# Altium schematic sheet 03-WWVB_Path.SchDoc(03-WWVB_Path)
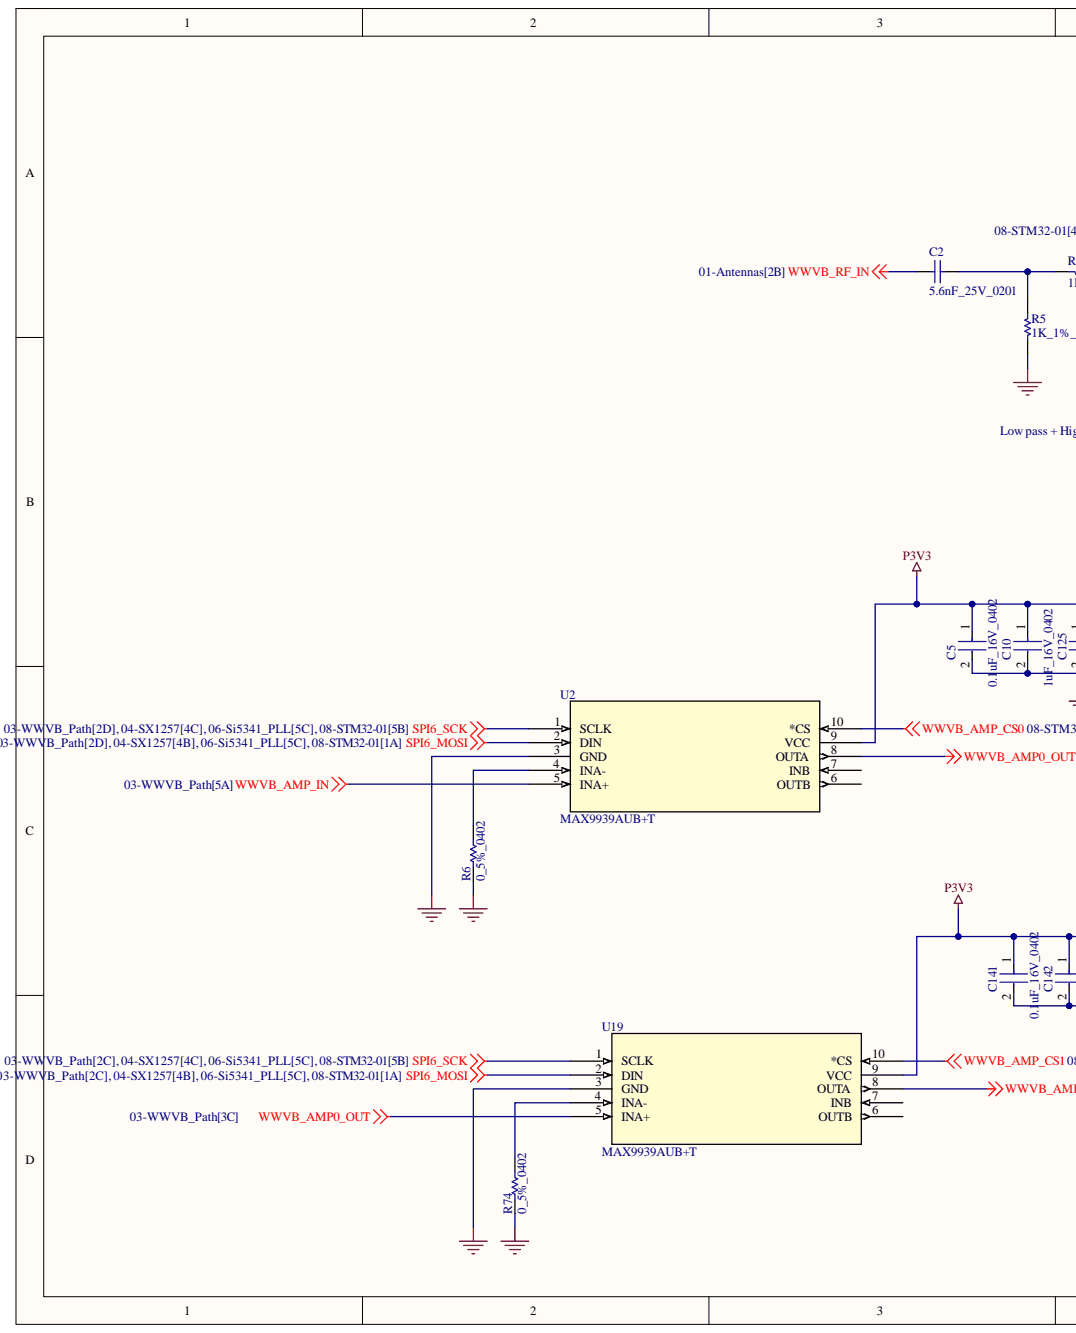
[diagram p0: 03-WWVB_Path.SchDoc, left]
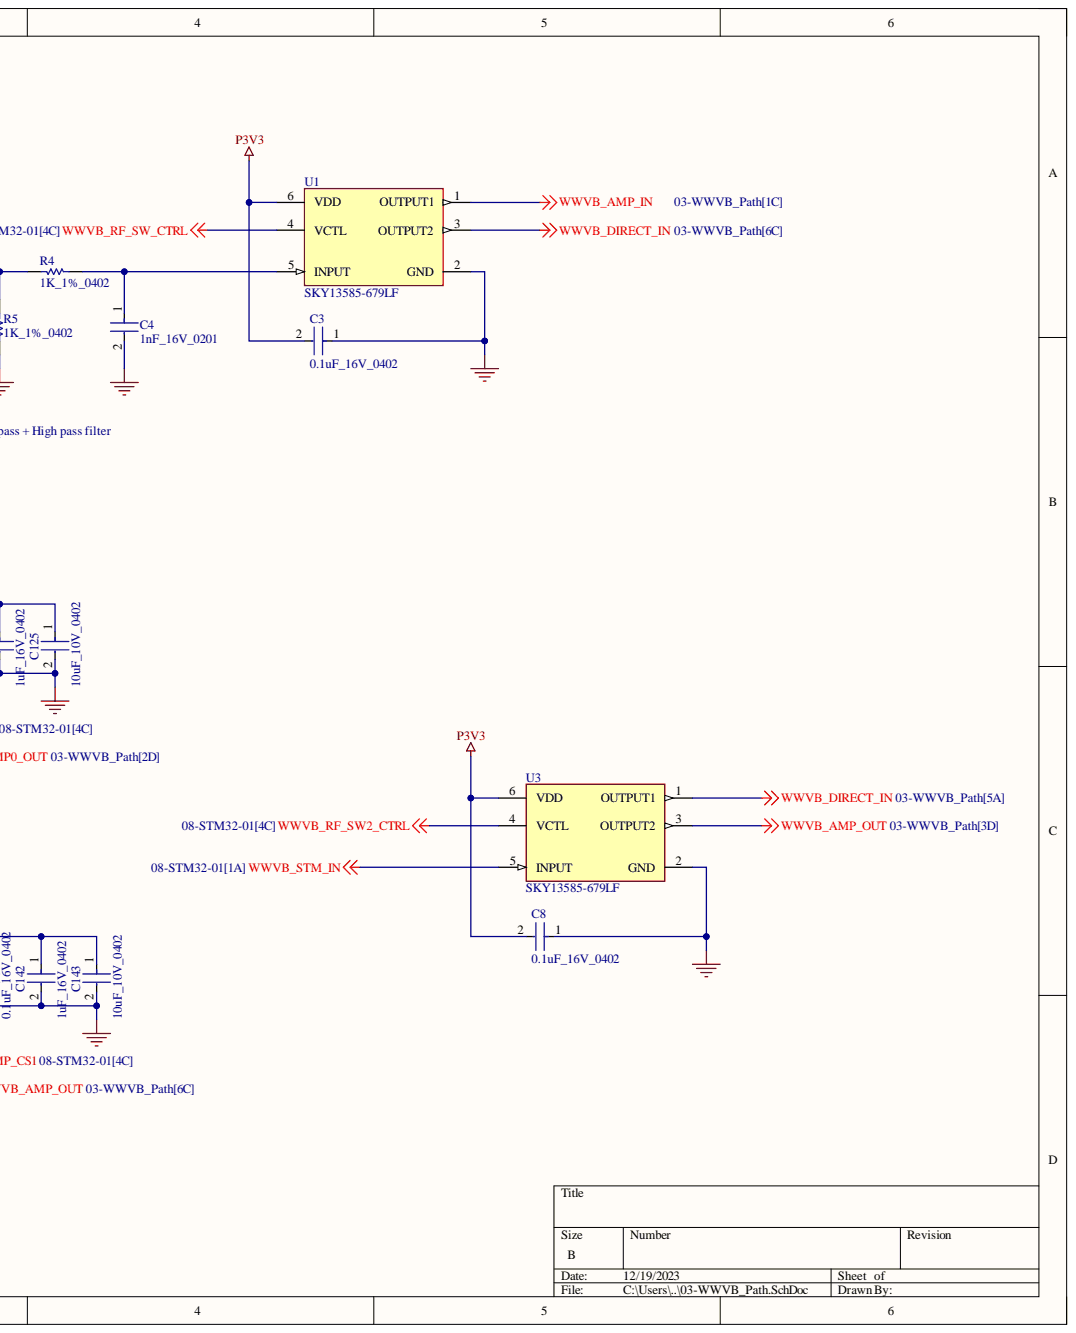
[diagram p1: 03-WWVB_Path.SchDoc, right]

## Parts
C2  5.6nF_25V_0201  [CAPC0603X33X15ML03T05]
C3  0.1uF_16V_0402  [FP-C0402-BB-MFG]
C4  1nF_16V_0201  [FP-0201-L_0_6_0_09-W_0_3-MFG]
C5  0.1uF_16V_0402  [FP-C0402-BB-MFG]
C8  0.1uF_16V_0402  [FP-C0402-BB-MFG]
C10  1uF_16V_0402  [FP-0402-L_1_0_0_05-W_0_5-IPC_A]
C125  10uF_10V_0402  [FP-CL05A106MP5NUNC-MFG]
C141  0.1uF_16V_0402  [FP-C0402-BB-MFG]
C142  1uF_16V_0402  [FP-0402-L_1_0_0_05-W_0_5-IPC_A]
C143  10uF_10V_0402  [FP-CL05A106MP5NUNC-MFG]
R4  1K_1%_0402  [RESC1005X40X25NL05T05]
R5  1K_1%_0402  [RESC1005X40X25NL05T05]
R6  0_5%_0402  [RESC1005X40X25NL05T10]
R74  0_5%_0402  [RESC1005X40X25NL05T10]
U1  SKY13585-679LF  [FP-SKY13585-679LF-MFG]
U2  MAX9939AUB+T  [21-0061L_MXM]
U3  SKY13585-679LF  [FP-SKY13585-679LF-MFG]
U19  MAX9939AUB+T  [21-0061L_MXM]

## Nets
GND: C3.1 C4.2 C5.2 C8.1 C10.2 C125.2 C141.2 C142.2 C143.2 R5.1 R6.1 R74.1 U1.2(GND) U2.3(GND) U3.2(GND) U19.3(GND)
P3V3: C3.2 C5.1 C8.2 C10.1 C125.1 C141.1 C142.1 C143.1 U1.6(VDD) U2.9(VCC) U3.6(VDD) U19.9(VCC)
SPI6_MOSI: U2.2(DIN) U19.2(DIN)
SPI6_SCK: U2.1(SCLK) U19.1(SCLK)
WWVB_AMP0_OUT: U2.8(OUTA) U19.5(INA+)
WWVB_AMP_CS0: U2.10(*CS)
WWVB_AMP_CS1: U19.10(*CS)
WWVB_AMP_IN: U1.1(OUTPUT1) U2.5(INA+)
WWVB_AMP_OUT: U3.3(OUTPUT2) U19.8(OUTA)
WWVB_DIRECT_IN: U1.3(OUTPUT2) U3.1(OUTPUT1)
WWVB_RF_IN: C2.1
WWVB_RF_SW2_CTRL: U3.4(VCTL)
WWVB_RF_SW_CTRL: U1.4(VCTL)
WWVB_STM_IN: U3.5(INPUT)
NetC2_2: C2.2 R4.2 R5.2
NetC4_1: C4.1 R4.1 U1.5(INPUT)
NetR6_2: R6.2 U2.4(INA-)
NetR74_2: R74.2 U19.4(INA-)

Not connected: U2 6(OUTB) 7(INB); U19 6(OUTB) 7(INB)

## Library data of the parts
C2 = CMP-2010-01745-1: Manufacturer: Multicomp; Part Number: MC000322; Component Kind: Standard; Library Name: Altium Content Vault
C3 C5 C8 C141 = CMP-1034-02068-3: CAP CER 0.1UF 16V 5% X7R 0402; Manufacturer: Kemet; Manufacturer Part Number: C0402C104J4RACTU; Capacitance: 0.10 μF; Material: Ceramic; Temperature Coefficient: 15%; Tolerance: 0.05; Value: 0.10 μF; Automotive: No; Category: Cap; Component Kind: Standard; ComponentLink2Description: Datasheet; ComponentLink2URL: https://content.kemet.com/datasheets/KEM_C1002_X7R_SMD.pdf; Device Class L1: Passive Components; Device Class L2: Capacitors; Device Class L3: Ceramic Capacitors; Digikey Description: CAP CER 0.1UF 16V 5% X7R 0402; Height: 0.55mm; Ipc Land Pattern Name: CAPC10050X50; Lead Free: Yes; Library Name: Altium Content Vault; Mouser Description: CAP CER 0.1UF 16V X7R 0402; Octopart: https://octopart.com/c0402c104j4ractu-kemet-12573893; Package: C0402-BB; RoHS: TRUE; Temperature: -55°C to +125°C; Temperature Characteristic: X7R; Temperature Range High: +125°C; Temperature Range Low: -55°C; Voltage Rating: 16 V
C4 = CMP-2010-00182-2: General Purpose Ceramic Capacitor, 0201, 1nF, 10%, X7R, 15%, 16V; Dissipation Factor: 12.5%; Insulation Resistance: 100GR; Capacitance: 1nF; Case Code (Imperial): 0201; Case Code (Metric): 0603; Case/Package: 0201; Component Kind: Standard; Construction: Flat; Depth: 0.3mm; Dielectric: X7R; Dielectric Material: Ceramic; Height: 0.33mm; Lead Free: Lead Free; Length: 0.6mm; Library Name: Altium Content Vault; Max Operating Temperature: 125°C; Min Operating Temperature: -55°C; Mount: Surface Mount; Number of Pins: 2; Package Quantity: 1; Packaging: Tape and Reel; Radiation Hardening: No; REACH SVHC: No SVHC; RoHS Compliant: Yes; Series: -; Termination: SMD/SMT; Thickness: 0.013inch; Tolerance: 10%; Voltage: 16V; Voltage Rating: 16V; Voltage Rating (DC): 16V; Width: 0.012inch
C10 C142 = CMP-14477-000104-2: None; Capacitance: 1uF; Dielectric: X5R; Tolerance: 10%; Voltage Rating: 16V; Case Code (Imperial): 0402; Case Code (Metric): 1005; Case/Package: 0402; Component Kind: Standard; Construction: Flat; Depth: 0.5mm; Halogen Free: Halogen Free; Height: 0.5mm; Length: 1mm; Library Name: Altium Content Vault; Max Operating Temperature: 85°C; Min Operating Temperature: -55°C; Mount: Surface Mount; Number of Pins: 2; Package Quantity: 1; Packaging: Tape and Reel; Radiation Hardening: No; RoHS Compliant: Yes; Series: M; Termination: SMD/SMT; Thickness: 0.022inch; Voltage Rating (DC): 16V; Width: 0.02inch
C125 C143 = CMP-13271-000002-1: CAP CER 10UF 10V X5R 0402; Manufacturer: Samsung; Manufacturer Part Number: CL05A106MP5NUNC; Material: Ceramic; Temperature Coefficient: 15%; Tolerance: 20%; Automotive: No; Capacitance: 10uF; Category: Cap; Component Kind: Standard; ComponentLink2Description: Datasheet; ComponentLink2URL: http://www.samsungsem.com/kr/support/product-search/mlcc/__icsFiles/afieldfile/2019/05/10/19-CL05A106MP5NUNC.pdf; Device Class L1: Passive Components; Device Class L2: Capacitors; Device Class L3: Ceramic Capacitors; Digikey Description: CAP CER 10UF 10V X5R 0402; Height: 0.7mm; Ipc Land Pattern Name: CAPC10050X50; Lead Free: Yes; Library Name: Altium Content Vault; Octopart: https://octopart.com/cl05a106mp5nunc-samsung-22457395; Package: CL05A106MP5NUNC; RoHS: TRUE; Temperature: -55°C to +85°C; Temperature Characteristic: X5R; Temperature Range High: +85°C; Temperature Range Low: -55°C; Value: 10uF; Voltage Rating: 10V
R4 R5 = CMP-2002-08277-1: Manufacturer: Vishay Dale; Part Number: CRCW04021K00FKTD; Component Kind: Standard; Library Name: Altium Content Vault
R6 R74 = CMP-2002-06457-1: Manufacturer: Panasonic; Part Number: ERJ-U020R00X; Component Kind: Standard; Library Name: Altium Content Vault
U1 U3 = CMP-85923-000009-1: IC RF SWITCH SPDT 6GHZ 6MLPD; IIP3: 56dBm; Impedance: 50R; Insertion Loss: 0.5dB; Test Frequency: 2.5GHz; Component Kind: Standard; Library Name: Altium Content Vault; Max Operating Temperature: 90°C; Min Operating Temperature: -40°C; Packaging: Cut Tape; RoHS Compliant: Yes; Series: -; Throw Configuration: SPDT
U2 U19 = MAX9939AUB+T: No Description Available; Mfr_Name: Analog Devices Inc; Component Kind: Standard; Library Name: MAX9939AUB&plus_T.SchLib; Manufacturer_Part_Number: MAX9939AUB+T; RefDes: RefDes; Type: DEV; Copyright: Copyright (C) 2023 Ultra Librarian. All rights reserved.
